# Altium schematic sheet Voltage Regulator.SchDoc
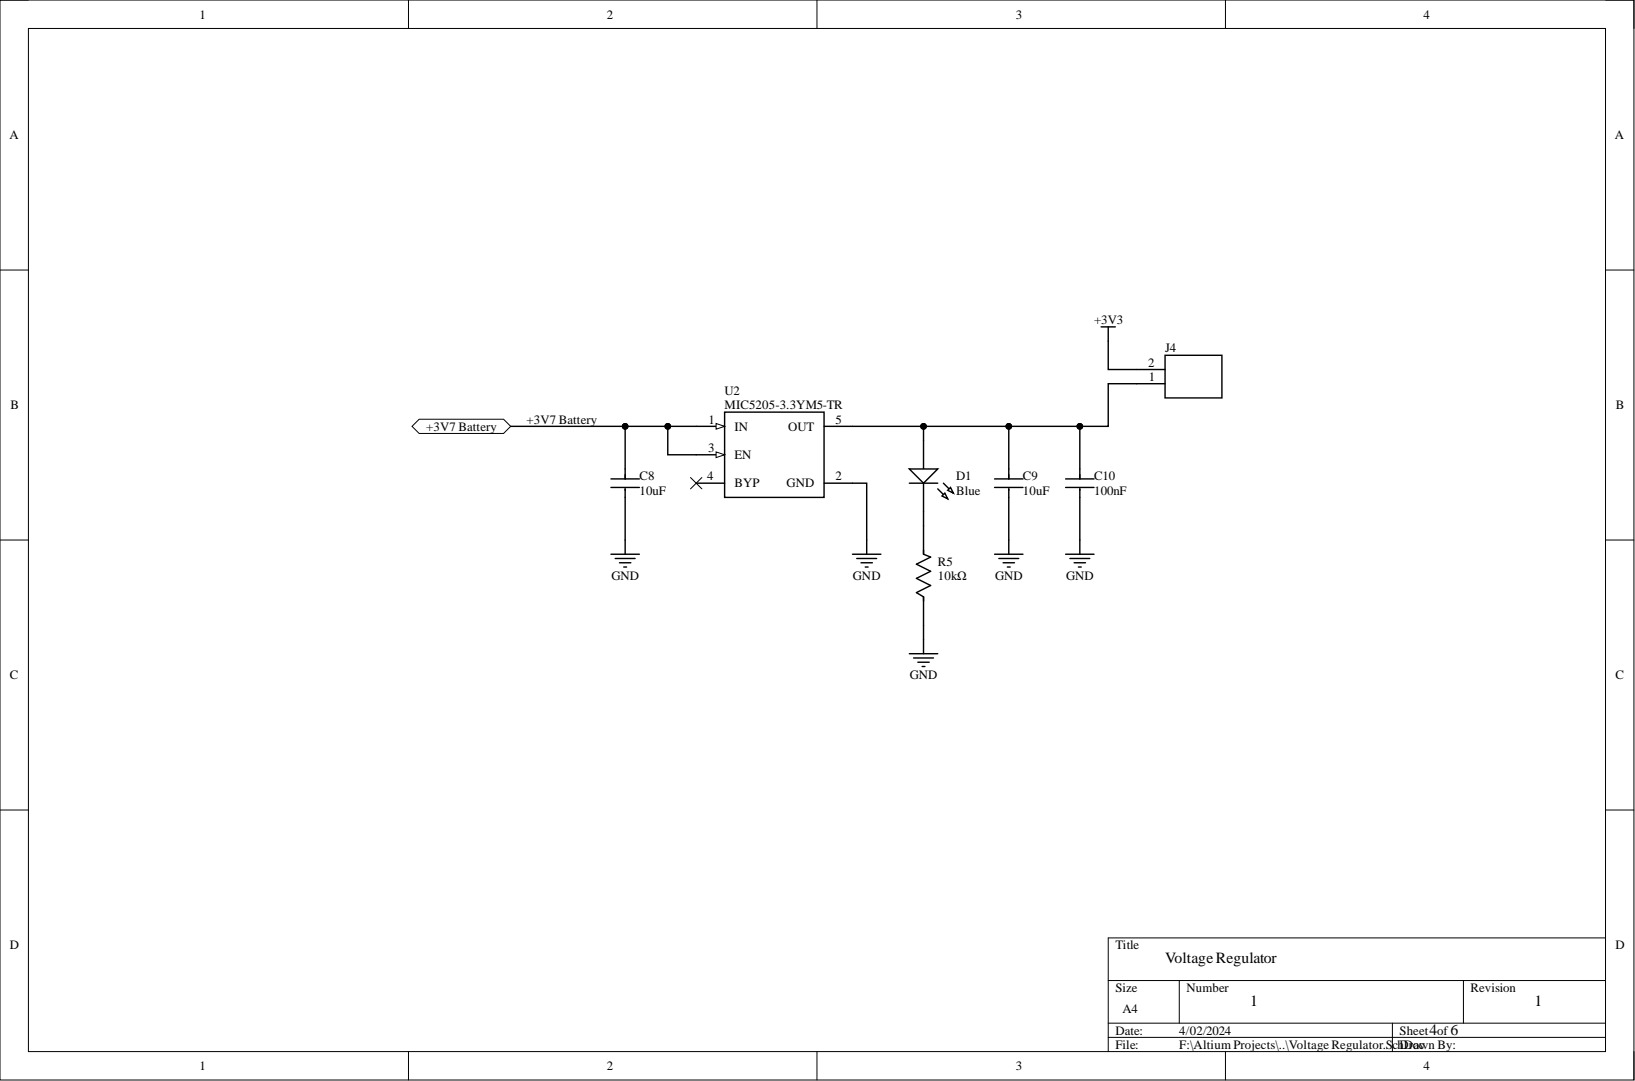
[diagram p0: Voltage Regulator.SchDoc]

## Parts
C8  Capacitance: 10uF  [FP-CL31-IPC_A]  (hidden comment: CL31F106ZPHNNNE)
C9  Capacitance: 10uF  [FP-CL31-IPC_A]  (hidden comment: CL31F106ZPHNNNE)
C10  value 100nF  [FP-C1206C-EB-MFG]  (hidden comment: C1206C104K5RAC7210)
D1  Color: Blue  [WL-SMCW_1206_150120xx75000]  (hidden comment: 150120BS75000)
J4  87527-2  [HDRV2W66P0X254_1X2_467X244X1044P]  (value not drawn)
R5  Resistance: 10kΩ  [FP-RNCP1206-IPC_B]  (hidden comment: RNCP1206FTD10K0)
U2  MIC5205-3.3YM5-TR  [FP-M5-MFG]

## Ports
+3V7 Battery

## Nets
+3V3: J4.2
GND: C8.2 C9.2 C10.2 R5.1 U2.2(GND)
+3V7 Battery: C8.1 U2.1(IN) U2.3(EN) ; port +3V7 Battery
NetC9_1: C9.1 C10.1 D1.2 J4.1 U2.5(OUT)
NetD1_1: D1.1 R5.2
NetU2_4: U2.4(BYP)

## Library data of the parts
C8 C9 = CMP-13271-001127-1: Cap Ceramic 10uF 10V Y5V -20/+80% SMD 1206 +85°C Embossed T/R; Tolerance: 80%; Case Code (Imperial): 1206; Case Code (Metric): 3216; Case/Package: 1206; Component Kind: Standard; Depth: 1.6mm; Dielectric: Y5V; Height: 1.6mm; Lead Free: Lead Free; Length: 3.2mm; Library Name: Altium Content Vault; Max Operating Temperature: 85°C; Min Operating Temperature: -30°C; Mount: Surface Mount; Package Quantity: 1; Packaging: Tape and Reel; Radiation Hardening: No; Thickness: 0.071inch; Voltage Rating: 10V; Voltage Rating (DC): 10V; Width: 0.063inch
C10 = CMP-03020-003377-1: CAP CER 0.1UF 50V X7R 1206; Manufacturer: KEMET; Automotive Grade: Grade 0; Capacitance: 0.10 μF; Material: Ceramic; Temperature Coefficient: 15%; Tolerance: 0.1; Automotive: Yes; Category: Cap; Component Kind: Standard; ComponentLink2Description: Datasheet; ComponentLink2URL: https://content.kemet.com/datasheets/KEM_C1007_X8R_ULTRA_150C_SMD.pdf; Device Class L1: Passive Components; Device Class L2: Capacitors; Device Class L3: Ceramic Capacitors; Digikey Description: CAP CER 0.1UF 50V X7R 1206; Height: 0.88mm; Ipc Land Pattern Name: CAPC320160X78; Lead Free: Yes; Library Name: Altium Content Vault; Manufacturer Part Number: C1206C104K5RAC7210; Mouser Description: Multilayer Ceramic Capacitors MLCC - SMD/SMT 50volts 0.1uF X7R 10%; Octopart: https://octopart.com/c1206c104k5rac7210-kemet-3919856; Package: C1206C-EB; RoHS: TRUE; Temperature: -55°C to +150°C; Temperature Characteristic: X7R; Temperature Range High: +150°C; Temperature Range Low: -55°C; Voltage Rating: 50 V
D1 = CMP-1426-00015-2: Manufacturer Package Identifier: LED_1206; NexarPartId: 29717786; Dominant Wavelength: 470nm; Forward Current: 100mA; Forward Voltage: 3.2V; Lens Style: Clear, Rectangular; Luminous Intensity: 145mcd; Max Reverse Voltage (DC): 5V; Peak Wavelength: 465nm; Test Current: 20mA; Viewing Angle: 140°; Case Code (Imperial): 1206; Case Code (Metric): 3216; Case/Package: 1206; Component Kind: Standard; Height: 680µm; Length: 3.2mm; Library Name: Altium Content Vault; Max Operating Temperature: 85°C; Min Operating Temperature: -40°C; Mount: Surface Mount; Packaging: Tape and Reel; REACH SVHC: Unknown; RoHS: Compliant; Wavelength: 470nm; Width: 1.6mm
J4 = 87527-2: Power isolation jumper; Manufacturer_Name: TE Connectivity; Arrow Part Number: 87527-2; Arrow Price/Stock: https://www.arrow.com/en/products/87527-2/te-connectivity?region=nac; Component Kind: Standard; Datasheet Link: https://www.te.com/commerce/DocumentDelivery/DDEController?Action=showdoc&DocId=Customer+Drawing%7F87527%7FH%7Fpdf%7FEnglish%7FENG_CD_87527_H.pdf%7F87527-2; Height: 10.439mm; Manufacturer_Part_Number: 87527-2; Mouser Part Number: 571-875272; Mouser Price/Stock: https://www.mouser.co.uk/ProductDetail/TE-Connectivity/87527-2?qs=ZDXmSm13593hsB4j%252B6gMfg%3D%3D
R5 = CMP-26527-005574-1: 10kΩ ±1% 0.5W 1206 High Power Anti-Sulfur Thin Film Chip Resistor; Max Power Dissipation: 500mW; Temperature Coefficient: 100ppm/°C; Case Code (Imperial): 1206; Case Code (Metric): 3216; Case/Package: 1206; Component Kind: Standard; Features: Moisture Resistant; Height: 0.024inch; Length: 0.122inch; Library Name: Altium Content Vault; Max Operating Temperature: 155°C; Min Operating Temperature: -55°C; Mount: Surface Mount; Number of Terminations: 2; Packaging: Tape and Reel; Resistor Type: Thin Film; RoHS Compliant: Yes; Series: RNCP; Tolerance: 1%; Width: 0.059inch
U2 = CMP-06324-000014-1: IC REG LINEAR 3.3V 150MA SOT23-5; Manufacturer: Microchip; Accuracy Percentage: 2%; Dropout Voltage: 165mV; Max Junction Temp: +125°C; Max Supply Voltage: 16V; Min Supply Voltage: 2.5V; Number Of Outputs: 1; Output Type: Fixed; Standoff Height: 0mm; Automotive: No; Component Kind: Standard; ComponentLink2Description: Datasheet; ComponentLink2URL: http://ww1.microchip.com/downloads/en/DeviceDoc/20005785A.pdf; Device Class L1: Integrated Circuits (ICs); Device Class L2: Power Management ICs; Device Class L3: Voltage Regulators - Linear; Digikey Description: IC REG LINEAR 3.3V 150MA SOT23-5; Height: 1.45mm; Ipc Land Pattern Name: SOT95P280X118-5; Lead Free: Yes; Library Name: Altium Content Vault; Manufacturer Part Number: MIC5205-3.3YM5-TR; Mouser Description: LDO Voltage Regulators; Octopart: https://octopart.com/mic5205-3.3ym5-tr-microchip-66802130; Output Current: 150mA; Package: M5; RoHS: TRUE; Temperature: -40°C to +125°C; Temperature Range High: +125°C; Temperature Range Low: -40°C
